# Altium schematic sheet Sheet6.SchDoc(Sheet6)
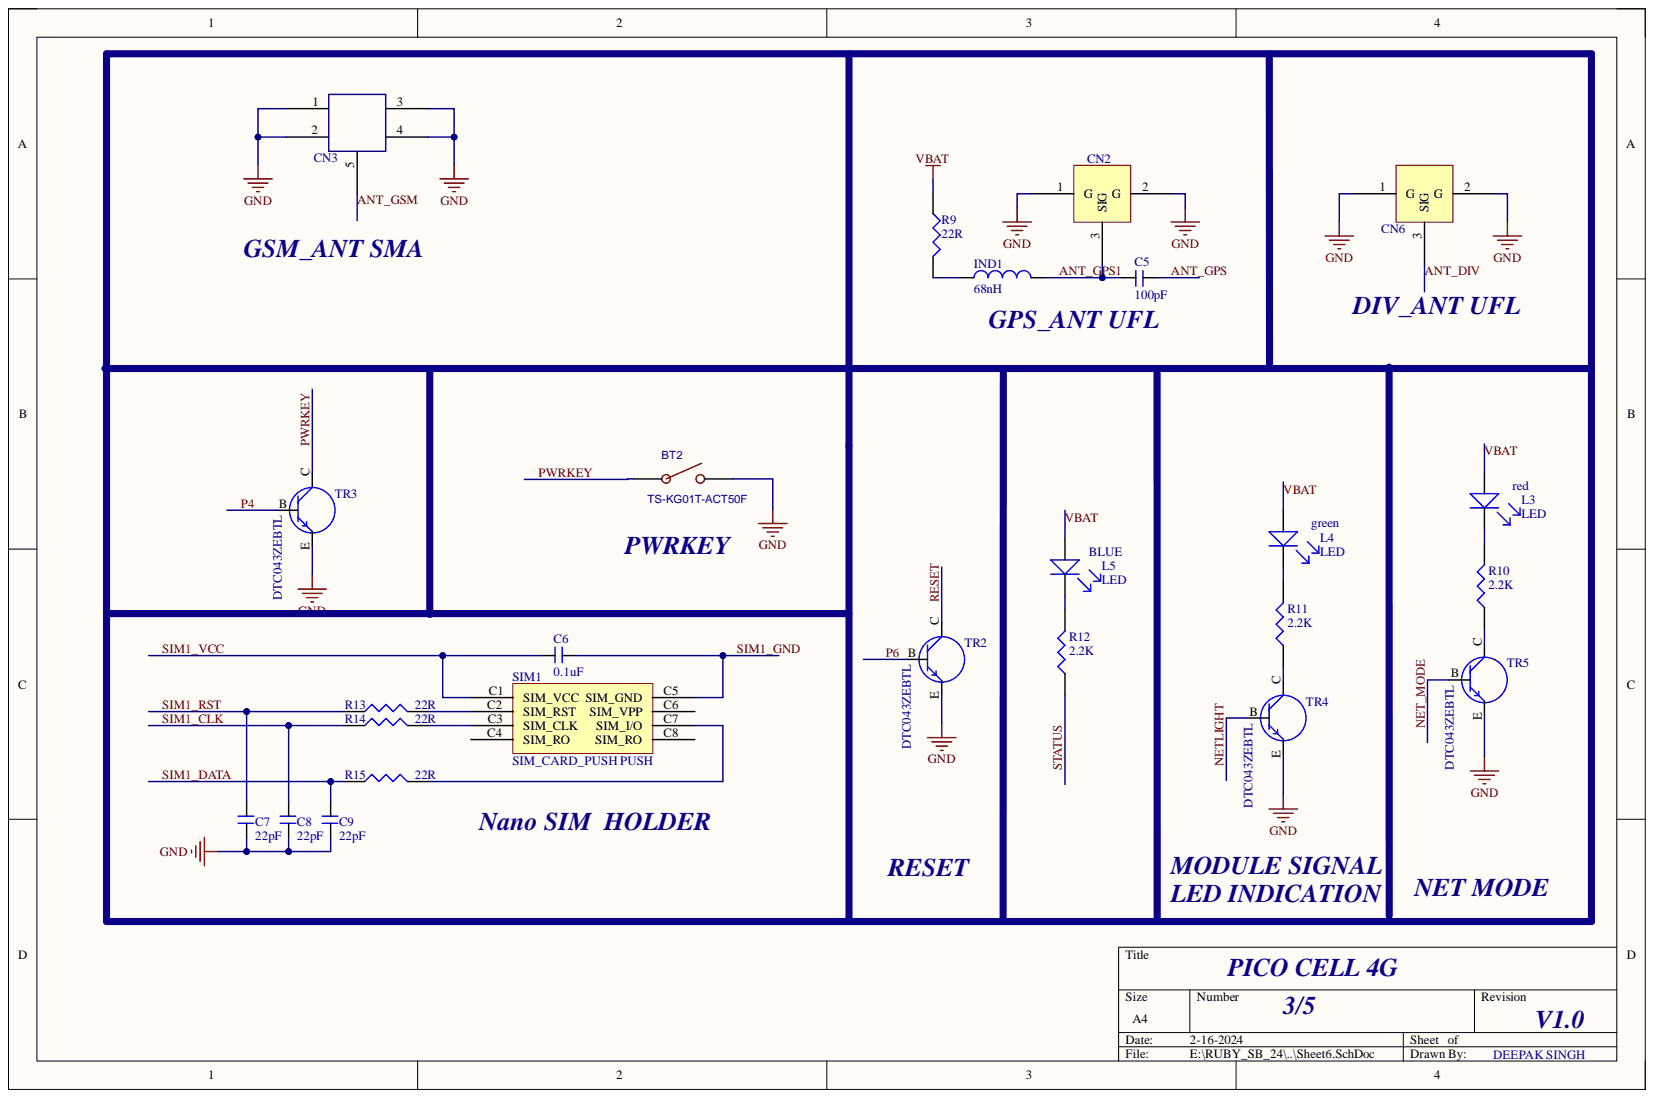
[diagram p0: Sheet6.SchDoc]

## Parts
BT2  TS-KG01T-ACT50F  [SW_2P SMD]
C5  100pF  [C_0603]
C6  0.1uF  [C_0603]
C7  22pF  [C_0603]
C8  22pF  [C_0603]
C9  22pF  [C_0603]
CN2  UFL CONNECTOR  [UFL]  (value not drawn)
CN3  SMA  CONNECTOR  [SMA_EDGE_SMD 1.6MM]  (value not drawn)
CN6  UFL CONNECTOR  [UFL]  (value not drawn)
IND1  68nH  [R_0603]
L3  LED  [LED_0603]
L4  LED  [LED_0603]
L5  LED  [LED_0603]
R9  22R  [R_0603]
R10  2.2K  [R_0603]
R11  2.2K  [R_0603]
R12  2.2K  [R_0603]
R13  22R  [R_0603]
R14  22R  [R_0603]
R15  22R  [R_0603]
SIM1  SIM_CARD_PUSH PUSH  [NANO-SIM_PUSH]
TR2  DTC043ZEBTL  [SOT-416]
TR3  DTC043ZEBTL  [SOT-416]
TR4  DTC043ZEBTL  [SOT-416]
TR5  DTC043ZEBTL  [SOT-416]

## Nets
GND: BT2.2 C7.2 C8.2 C9.2 CN2.1 CN2.2 CN3.1 CN3.2 CN3.3 CN3.4 CN6.1 CN6.2 TR2.E TR3.E TR4.E TR5.E
ANT_DIV: CN6.3
ANT_GPS: C5.2
ANT_GPS1: C5.1 CN2.3 IND1.2
ANT_GSM: CN3.5
NET_MODE: TR5.B
NETLIGHT: TR4.B
P4: TR3.B
P6: TR2.B
PWRKEY: BT2.1 TR3.C
RESET: TR2.C
SIM1_CLK: C8.1 R14.1
SIM1_DATA: C9.1 R15.1
SIM1_GND: C6.1 SIM1.C5(SIM_GND)
SIM1_RST: C7.1 R13.1
SIM1_VCC: C6.2 SIM1.C1(SIM_VCC)
STATUS: R12.1
VBAT: L3.A L4.A L5.A R9.1
NetIND1_1: IND1.1 R9.2
NetL3_K: L3.K R10.2
NetL4_K: L4.K R11.2
NetL5_K: L5.K R12.2
NetR10_1: R10.1 TR5.C
NetR11_1: R11.1 TR4.C
NetR13_2: R13.2 SIM1.C2(SIM_RST)
NetR14_2: R14.2 SIM1.C3(SIM_CLK)
NetR15_2: R15.2 SIM1.C7(SIM_I/O)

Not connected: SIM1 C4(SIM_RO) C6(SIM_VPP) C8(SIM_RO)

## Library data of the parts
BT2 = JT1185SAEM: BOM_Manufacturer: NAMAE(韩国南涯); Contributor: LCSC; BOM_JLCPCB Part Class: Extended Part; BOM_Manufacturer Part: JT1185SAEM; BOM_Supplier: LCSC; BOM_Supplier Part: C465068; Component Kind: Standard; spicePre: S; spiceSymbolName: JT1185SAEM
C5-C9 = CAP_NONPOLAR: Component Kind: Standard; Library Name: dxp_pasl_lib.IntLib
CN2 CN6 = UFL_CONNECTOR: Component Kind: Standard; Library Name: dxp_pasl_lib.IntLib
CN3 = SMA CONNECTOR: Component Kind: Standard; Library Name: dxp_pasl_lib.IntLib
IND1 = INDUCTOR: Component Kind: Standard; Library Name: dxp_pasl_lib.IntLib
R9-R15 = RES: Component Kind: Standard; Library Name: dxp_pasl_lib.IntLib
SIM1 = SIM_CARD_MICRO: Component Kind: Standard; Library Name: dxp_pasl_lib.SCHLIB
TR2-TR5 = DTC043ZEBTL: Pre-Biased Bipolar Transistor (BJT) NPN Pre-Biased 100mA 50V 150mW SOT-416FL Digital Transistors RoHS # C96459; Component Kind: Standard; Library Name: dxp_pasl_lib.IntLib
L3-L5 = LED: Component Kind: Standard; Library Name: dxp_pasl_lib.IntLib
